# Altium schematic sheet RFM69_PCB.SchDoc
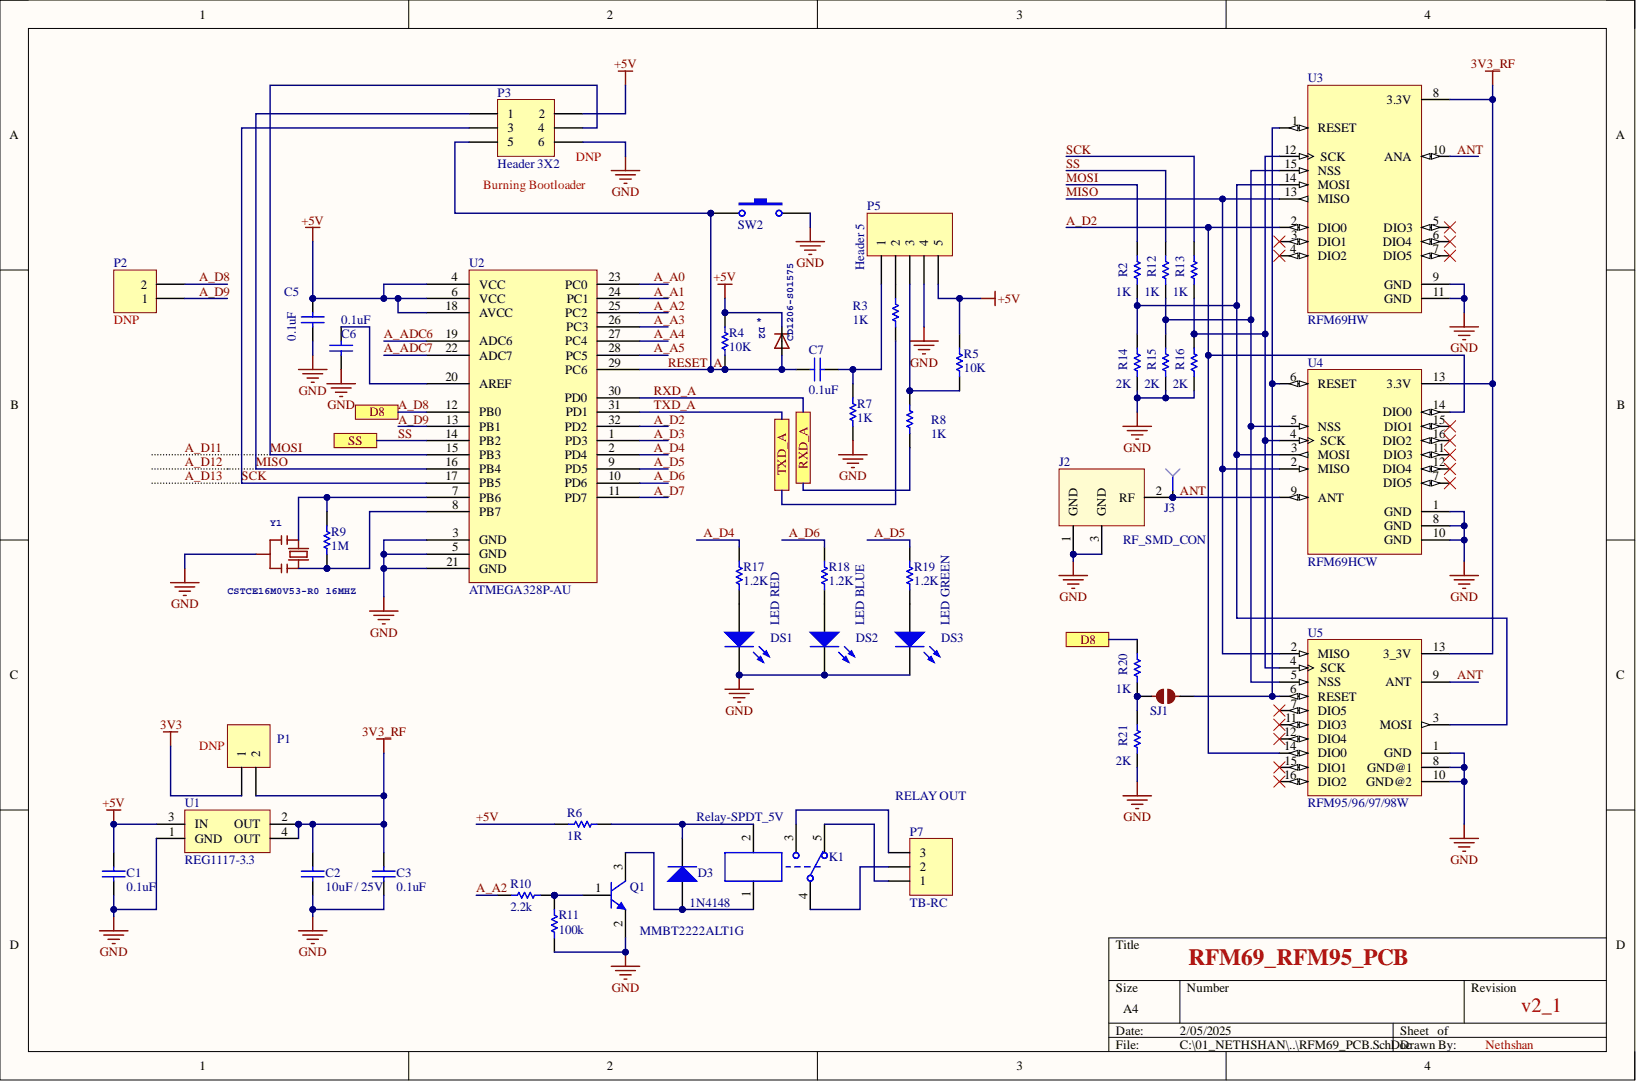
[diagram p0: RFM69_PCB.SchDoc]

## Parts
C1  value 0.1uF  [CAPC1608X08L(0603)]  (hidden comment: Cap 0603)
C2  value 10uF / 25V  [CAPC2012X07L(0805)]  (hidden comment: Cap 0805)
C3  value 0.1uF  [CAPC1608X08L(0603)]  (hidden comment: Cap 0603)
C5  0.1uF  [CAPC1608L]
C6  value 0.1uF  [CAPC1608L]  (hidden comment: Capacitor Ceramic 0.10uF 10% 16V X7R 0603)
C7  value 0.1uF  [CAPC1608L]  (hidden comment: Capacitor Ceramic 0.10uF 10% 16V X7R 0603)
D2  CD1206-S01575  [CD3216-1206]
D3  1N4148  [MELF-D3513-1405]
DS1  value LED RED  [LED Red 3MM]  (hidden comment: LED RED 3MM)
DS2  value LED BLUE  [LED Blue 3MM]  (hidden comment: LED BLUE 3MM)
DS3  value LED GREEN  [LED Green 3MM]  (hidden comment: LED GREEN 3MM)
J2  RF_SMD_CON  [RF_SMD_CON]
J3  Socket  [Socket Type A]  (value not drawn)
K1  Relay-SPDT_5V  [Relay-SPDT_5V]
P1  soldering instructions: DNP  [HDR1X2]  (hidden comment: Header 2)
P2  soldering instructions: DNP  [HDR1X2]  (hidden comment: Header 2)
P3  Header 3X2  [HDR2X3]
P5  Header 5  [HDR1X5]
P7  TB-RC  [3-Pin TB Rising Clamp Vertical]
Q1  MMBT2222ALT1G  [SOT23-3L]
R2  value 1K  [RESC1608X05L(0603)]  (hidden comment: Res 0603)
R3  value 1K  [J1-0603]  (hidden comment: Res 0603)
R4  value 10K  [J1-0603]  (hidden comment: Res 0603)
R5  value 10K  [J1-0603]  (hidden comment: Res 0603)
R6  value 1R  [RESC3216L]  (hidden comment: Res 1206)
R7  value 1K  [J1-0603]  (hidden comment: Res 0603)
R8  value 1K  [J1-0603]  (hidden comment: Res 0603)
R9  value 1M  [J1-0603]  (hidden comment: Res 0603)
R10  value 2.2k  [J1-0603]  (hidden comment: Res 0603)
R11  value 100k  [J1-0603]  (hidden comment: Res 0603)
R12  value 1K  [RESC1608X05L(0603)]  (hidden comment: Res 0603)
R13  value 1K  [RESC1608X05L(0603)]  (hidden comment: Res 0603)
R14  value 2K  [RESC1608X05L(0603)]  (hidden comment: Res 0603)
R15  value 2K  [RESC1608X05L(0603)]  (hidden comment: Res 0603)
R16  value 2K  [RESC1608X05L(0603)]  (hidden comment: Res 0603)
R17  value 1.2K  [RESC3216L]  (hidden comment: Res 0603)
R18  value 1.2K  [RESC3216L]  (hidden comment: Res 0603)
R19  value 1.2K  [RESC3216L]  (hidden comment: Res 0603)
R20  value 1K  [RESC1608X05L(0603)]  (hidden comment: Res 0603)
R21  value 2K  [RESC1608X05L(0603)]  (hidden comment: Res 0603)
SJ1  SOLDER JUMPER NO S  [SJ-2SS-NO]  (value not drawn)
SW2  SW-PB_RA_TH  [SW-PB-RA-TH]  (value not drawn)
U1  REG1117-3.3  [ZZ311]
U2  ATMEGA328P-AU  [TQFP80P900X900X120-32L]
U3  RFM69HW  [RFM69HW]
U4  RFM69HCW  [RFM69HCW]
U5  RFM95/96/97/98W  [RFM95/96/97/98W]
Y1  CSTCE16M0V53-R0 16MHZ  MFGPN: CSTCE16M0V53-R0  [RESONATOR]

## Ports
D8, RXD_A, SS, TXD_A

## Nets
3V3: P1.1
3V3_RF: C2.2 C3.2 P1.2 U1.2(OUT) U1.4(OUT) U3.8(3.3V) U4.13(3.3V) U5.13(3_3V)
GND: C1.1 C2.1 C3.1 C5.1 C6.1 DS1.2 DS2.2 DS3.2 J2.1 J2.3 P3.6 P5.4 Q1.2 R7.2 R11.2 R14.2 R15.2 R16.2 R21.2 SW2.2 U1.1(GND) U2.3(GND) U2.5(GND) U2.21(GND) U3.9(GND) U3.11(GND) U4.1(GND) U4.8(GND) U4.10(GND) U5.1(GND) U5.8(GND@1) U5.10(GND@2) Y1.2
+5V: C1.2 C5.2 D2.C P3.2 P5.5 R4.1 R5.2 R6.1 U1.3(IN) U2.4(VCC) U2.6(VCC) U2.18(AVCC)
A_A0: U2.23(PC0)
A_A1: U2.24(PC1)
A_A2: R10.2 U2.25(PC2)
A_A3: U2.26(PC3)
A_A4: U2.27(PC4)
A_A5: U2.28(PC5)
A_ADC6: U2.19(ADC6)
A_ADC7: U2.22(ADC7)
A_D2: U2.32(PD2) U3.2(DIO0) U4.14(DIO0) U5.14(DIO0)
A_D3: U2.1(PD3)
A_D4: R17.2 U2.2(PD4)
A_D5: R19.2 U2.9(PD5)
A_D6: R18.2 U2.10(PD6)
A_D7: U2.11(PD7)
A_D8: P2.2 R20.1 U2.12(PB0) ; port D8
A_D9: P2.1 U2.13(PB1)
ANT: J2.2 J3.0 U3.10(ANA) U4.9(ANT) U5.9(ANT)
MISO: P3.1 U2.16(PB4) U3.13(MISO) U4.2(MISO) U5.2(MISO)
MOSI: P3.4 R2.1 U2.15(PB3)
RESET_A: C7.2 D2.A P3.5 R4.2 SW2.1 U2.29(PC6)
RXD_A: R8.2 U2.30(PD0) ; port RXD_A
SCK: P3.3 R13.1 U2.17(PB5)
SS: R12.1 U2.14(PB2) ; port SS
TXD_A: R3.2 U2.31(PD1) ; port TXD_A
NetC6_2: C6.2 U2.20(AREF)
NetC7_1: C7.1 P5.1 R7.1
NetD3_1: D3.1 K1.1 Q1.3
NetD3_2: D3.2 K1.2 R6.2
NetDS1_1: DS1.1 R17.1
NetDS2_1: DS2.1 R18.1
NetDS3_1: DS3.1 R19.1
NetK1_3: K1.3 P7.3
NetK1_4: K1.4 P7.2
NetK1_5: K1.5 P7.1
NetP5_2: P5.2 R3.1
NetP5_3: P5.3 R5.1 R8.1
NetQ1_1: Q1.1 R10.1 R11.1
NetR2_2: R2.2 R14.1 U3.14(MOSI) U4.3(MOSI) U5.3(MOSI)
NetR9_1: R9.1 U2.7(PB6) Y1.1
NetR9_2: R9.2 U2.8(PB7) Y1.3
NetR12_2: R12.2 R15.1 U3.15(NSS) U4.5(NSS) U5.5(NSS)
NetR13_2: R13.2 R16.1 U3.12(SCK) U4.4(SCK) U5.4(SCK)
NetR20_2: R20.2 R21.1 SJ1.1
NetSJ1_2: SJ1.2 U3.1(RESET) U4.6(RESET) U5.6(RESET)
A_D11:
A_D12:
A_D13:

Not connected: U3 3(DIO1) 4(DIO2) 5(DIO3) 6(DIO4) 7(DIO5); U4 7(DIO5) 11(DIO3) 12(DIO4) 15(DIO1) 16(DIO2); U5 7(DIO5) 11(DIO3) 12(DIO4) 15(DIO1) 16(DIO2)

## Library data of the parts
C1 C3 = Cap Semi_2: CAP CER 0.1UF 25V X7R 0603; Manufacturer: Yageo; Discription: 0.1µF ±5% 25V Ceramic Capacitor X7R 0603 (1608 Metric); MFGPN: CC0603JRX7R8BB104; Vendor: Digi-Key; VPN: 311-1777-1-ND; Component Kind: Standard; PackageReference: CAPC1608X08L(0603); Signal Integrity: Cap Semi; Simulation: CAP; Supplier 1: Digi-Key; Supplier 2: Farnell; Supplier 3: LCSC; Supplier Part Number 1: 311-1777-1-ND; Supplier Part Number 3: C327087; Library Name: BarcodeReaderGSM_PCB_v1_0_2.SCHLIB
C2 = Cap Semi_2: CAP CER 10UF 25V X5R 0805; Manufacturer: Yageo; Discription: 10µF ±10% 25V Ceramic Capacitor X5R 0805 (2012 Metric); MFGPN: CC0805KKX5R8BB106; Value: 10uF / 25V; Vendor: Digi-Key; VPN: 311-1869-1-ND; Component Kind: Standard; PackageReference: CAPC2012X07L(0805); Signal Integrity: Cap Semi; Simulation: CAP; Supplier 1: Digi-Key; Supplier 2: Farnell; Supplier 3: LCSC; Supplier Part Number 1: 311-1869-1-ND; Supplier Part Number 2: 3366590; Supplier Part Number 3: C89831; Library Name: BarcodeReaderGSM_PCB_v1_0_2.SCHLIB
C5 = Cap Semi: Capacitor Ceramic 0.10uF 10% 16V X7R 0603; Manufacturer: Panasonic; MFGPN: ECJ-1VB1C104K; Vendor: Digikey; VPN: PCC1762CT-ND; Component Kind: Standard; Signal Integrity: Cap Semi; Simulation: CAP; Value: 0.1uF; Library Name: GSM_SIM800_2.SCHLIB
C6 C7 = Cap Semi: Manufacturer: Panasonic; MFGPN: ECJ-1VB1C104K; Vendor: Digikey; VPN: PCC1762CT-ND; Component Kind: Standard; Description: Capacitor Ceramic 0.10uF 10% 16V X7R 0603; Signal Integrity: Cap Semi; Simulation: CAP; Library Name: GSM_SIM800_2.SCHLIB
D2 = DIODE-MINIMELF: DIODE GEN PURP 100V 150MA 1206; Manufacturer: Bourns Inc.; Vendor: D; VPN: CD1206-S01575TR-ND; Component Kind: Standard; Library Name: diode.IntLib; MFGPN: CD1206-S01575; Value: 100V 150MA
D3 = Diode 1N4148: DIODE GEN PURP 100V 200MA SOD80; Manufacturer: ON Semiconductor; Discription: Diode Standard 100V 200mA Surface Mount SOD-80; MFGPN: LL4148; Value: LL4148; Vendor: Digi-Key; VPN: LL4148FSTR-ND; Component Kind: Standard; PackageReference: DO-35; Signal Integrity: 1N4148; Simulation: 1N4148; Supplier 1: Digi-Key; Supplier 2: Farnell; Supplier Part Number 1: LL4148FSTR-ND; Supplier Part Number 2: 2822552; Library Name: TC_Dim&2Way_PCB.SCHLIB
DS1 = LED_Red_3MM: LED RED DIFFUSED 3MM T/H; Manufacturer: Vishay Semiconductor Opto Division; MFGPN: TLHR4400; Vendor: Digikey; VPN: 751-1127-ND; Component Kind: Standard; Library Name: N_20170921.SchLib; Simulation: LED2
DS2 = LED_Blue_3MM: Manufacturer: Vishay Semiconductor Opto Division; Vendor: Digikey; Component Kind: Standard; Library Name: N_20170921.SchLib; Simulation: LED2
DS3 = LED_Green_3MM: LED GREEN DIFFUSED 3MM T/H; Manufacturer: Vishay Semiconductor Opto Division; MFGPN: TLHG4400; Vendor: Digikey; VPN: 751-1099-ND; Component Kind: Standard; Library Name: N_20170921.SchLib; Simulation: LED2
J2 = RF_SMD_CON: Manufacturer: Taoglas Limited; MFGPN: RECE.20279.001E.01; Value: RECE.20279.001E.01; Vendor: Digikey; VPN: 931-1107-1-ND; Component Kind: Standard; Description: RF_SMD_CON; Supplier 1: Digi-Key; Supplier 2: Farnell; Supplier 3: LCSC-ALT; Supplier Part Number 3: C88374; Library Name: Schlib_GSM_SIM800.SchLib
J3 = Socket_A: Socket Type A; Component Kind: Standard; Supplier 3: LCSC; Library Name: PLM_Tx3_v1_1.SCHLIB
K1 = Relay-SPDT: Single-Pole Dual-Throw Relay; Component Kind: Standard; LatestRevisionDate: 17-Jul-2002; LatestRevisionNote: Re-released for DXP Platform.; Library Name: N_20170921.SchLib; PackageDescription: Relay; 8 Leads; PackageReference: DIP-P8; Published: 24-Mar-1999; Simulation: DPDTRELAY; Publisher: Altium Limited
P1 P2 = Header 2: Header, 2-Pin; Component Kind: Standard; LatestRevisionDate: 17-Jul-2002; LatestRevisionNote: Re-released for DXP Platform.; Library Name: Miscellaneous Connectors.IntLib; Signal Integrity: Connector; Supplier 3: LCSC; Publisher: Altium Limited
P3 = Header 3X2: Header, 3-Pin, Dual row (VERTICAL PC TAIL PIN HEADER); MFGPN: M20-9980346; Component Kind: Standard; LatestRevisionDate: 17-Jul-2002; LatestRevisionNote: Re-released for DXP Platform.; Library Name: Miscellaneous Connectors.IntLib; Signal Integrity: Connector; Publisher: Altium Limited
P5 = Header 5: Header, 5-Pin (VERTICAL PC TAIL PIN HEADER); MFGPN: M20-9990546; Component Kind: Standard; LatestRevisionDate: 17-Jul-2002; LatestRevisionNote: Re-released for DXP Platform.; Library Name: Miscellaneous Connectors.IntLib; Signal Integrity: Connector; Publisher: Altium Limited
P7 = TB-3_Rising Clamp: TERMINAL BLOCK 5.08MM 3POS PCB Rising Clamp; Component Kind: Standard; Library Name: N_20170921.SchLib
Q1 = BC849C: TRANS NPN 40V 0.6A SOT23; Manufacturer: ON Semiconductor; Vendor: Digikey; VPN: MMBT2222ALT1GOSTR-ND; Component Kind: Standard; MFGPN: MMBT2222ALT1G; Signal Integrity: BC849C; Value: MMBT2222ALT1G; Library Name: PS_UK.SCHLIB
R2 R12 R13 R20 = Res1: RES SMD 1K OHM 1% 1/10W 0603; Manufacturer: Yageo; Discription: 1 kOhms ±1% 0.1W, 1/10W Chip Resistor 0603 (1608 Metric) Moisture Resistant Thick Film; MFGPN: RC0603FR-071KL; Vendor: Digi-Key; VPN: 311-1.00KHRCT-ND; Component Kind: Standard; Library Name: Miscellaneous Devices.IntLib; PackageReference: RESC1608X05L(0603); Signal Integrity: Res; Simulation: RESISTOR; Supplier 1: Digi-Key; Supplier 2: Farnell; Supplier Part Number 1: 311-1.00KHRCT-ND; Supplier Part Number 2: 9238484
R3 R7 R8 = Res1: RES SMD 1K OHM 5% 1/10W 0603; Manufacturer: Panasonic; MFGPN: ERJ-3GEYJ102V; Vendor: Digikey; VPN: P1.0KGTR-ND; Component Kind: Standard; Library Name: Miscellaneous Devices.IntLib; Signal Integrity: Res; Simulation: RESISTOR
R4 R5 = Res1: RES SMD 10K OHM 5% 1/10W 0603; Manufacturer: Panasonic; MFGPN: ERJ-3GEYJ103V; Vendor: Digikey; VPN: P10KGTR-ND; Component Kind: Standard; Library Name: Miscellaneous Devices.IntLib; Signal Integrity: Res; Simulation: RESISTOR
R6 = Res1: RES SMD 1 OHM 5% 1/2W 1206; Manufacturer: Panasonic; MFGPN: ERJ-8BQJ1R0V; Vendor: Digikey; VPN: P17638TR-ND; Component Kind: Standard; Signal Integrity: Res; Simulation: RESISTOR; Library Name: BarcodeReaderGSM_PCB_v1_0_2.SCHLIB
R9 = Res1: RES SMD 1M OHM 5% 1/10W 0603; Manufacturer: Panasonic; MFGPN: ERJ-3GEYJ105V; Vendor: Digikey; VPN: P1.0MGTR-ND; Component Kind: Standard; Library Name: Miscellaneous Devices.IntLib; Signal Integrity: Res; Simulation: RESISTOR
R10 = Res1: RES SMD 2.2K OHM 5% 1/10W 0603; Manufacturer: Panasonic; MFGPN: ERJ-3GEYJ222V; Vendor: Digikey; VPN: P2.2KGTR-ND; Component Kind: Standard; Library Name: Miscellaneous Devices.IntLib; Signal Integrity: Res; Simulation: RESISTOR
R11 = Res1: RES SMD 100K OHM 5% 1/10W 0603; Manufacturer: Panasonic; MFGPN: ERJ-3GEYJ104V; Vendor: Digikey; VPN: P100KGTR-ND; Component Kind: Standard; Signal Integrity: Res; Simulation: RESISTOR; Library Name: BarcodeReaderGSM_PCB_v1_0_2.SCHLIB
R14-R16 R21 = Res1: RES SMD 2K OHM 1% 1/10W 0603; Manufacturer: Yageo; Discription: 2 kOhms ±1% 0.1W, 1/10W Chip Resistor 0603 (1608 Metric) Moisture Resistant Thick Film; MFGPN: RC0603FR-072KL; Vendor: Digi-Key; VPN: 311-2.00KHRCT-ND; Component Kind: Standard; Library Name: Miscellaneous Devices.IntLib; PackageReference: RESC1608X05L(0603); Signal Integrity: Res; Simulation: RESISTOR; Supplier 1: Digi-Key; Supplier 2: Farnell; Supplier Part Number 1: 311-2.00KHRCT-ND; Supplier Part Number 2: 1799328
R17-R19 = Res1: RES SMD 1.2K OHM 5% 1/10W 0603; Manufacturer: Panasonic; Vendor: Digikey; Component Kind: Standard; Library Name: Miscellaneous Devices.IntLib; Signal Integrity: Res; Simulation: RESISTOR
SJ1 = SOLDER JUMPER NO S: Solder JumperStandard SMD solder jumper. Normally Open, Small; Component Kind: Standard; Library Name: N_20170921.SchLib; Supplier 3: LCSC
SW2 = SW-PB_RA_TH: SWITCH TACTILE SPST-NO 0.05A 12V TH; Value: 0.05A @ 12VDC; Component Kind: Standard; Discription: SWITCH TACTILE SPST-NO 0.05A 12V; Library Name: N_20170921.SchLib
U1 = REG1117-3.3: 800mA and 1A Low Dropout Positive Regulator 2.85V, 3.3V, 5V, and Adjustable; Manufacturer: Texas Instruments; Discription: Fixed 15V 3.3V 1.2V @ 800mA SOT-223 Dropout Regulators(LDO) RoHS; MFGPN: LM1117IMP-3.3/NOPB; Component Kind: Standard; ComponentLink1Description: Manufacturer Link; ComponentLink1URL: http://www.ti.com/; ComponentLink2Description: Datasheet; ComponentLink2URL: http://www-s.ti.com/sc/ds/REG1117.pdf; DatasheetVersion: Sep-1997; LatestRevisionDate: 17-Jul-2002; LatestRevisionNote: Re-released for DXP Platform.; Library Name: BB Power Mgt Voltage Regulator.IntLib; PackageDescription: SOT-223/SC-73; 4 Leads; Body 6.7 x 7.3 mm, inc. leads (LxW max); PackageReference: ZZ311; PackageVersion: revC; Published: 8-Jun-2000; Supplier 1: Digi-Key; Supplier 2: Farnell; Supplier 3: LCSC; Supplier Part Number 3: C129334
U2 = ATMEGA328P-AU: ATMEL 8-BIT MICROCONTROLLER; Component Kind: Standard; Library Name: N_20170921.SchLib
U3 = RFM69HW: MF: RF; MP: RFM69HW-868S2; Availability: Unavailable; Component Kind: Standard; Description: RFM69HW; Library Name: N_20170921.SchLib; Package: SMD-16 RF Solutions; Price: None; SnapEDA_Link: https://www.snapeda.com/parts/RFM69HW-868S2/RF%20Solutions/view-part/367203/?ref=snap
U4 = RFM69HCW: Rfm69hcw Wireless Transceiver 91; MP: COM-13909; Availability: Unavailable; Component Kind: Standard; Library Name: N_20170921.SchLib; Package: None; Price: None
U5 = RFM95/96/97/98W: RFM95/96/97/98W Mod Txrx Lora +20dbm 868mhz Smd; MP: RFM95W-868S2; Availability: Unavailable; Component Kind: Standard; Library Name: N_20170921.SchLib; Package: None; Price: None
Y1 = RESONATORMU: CER RES 16.0000MHZ 15PF SMD; Manufacturer: Murata Electronics; Vendor: D; VPN: 490-1198-1-ND; Component Kind: Standard; Library Name: arduino_Uno_Rev3-02-TH.SCHLIB; Value: 16.0000MHZ
